# Altium schematic sheet Sheet2.SchDoc
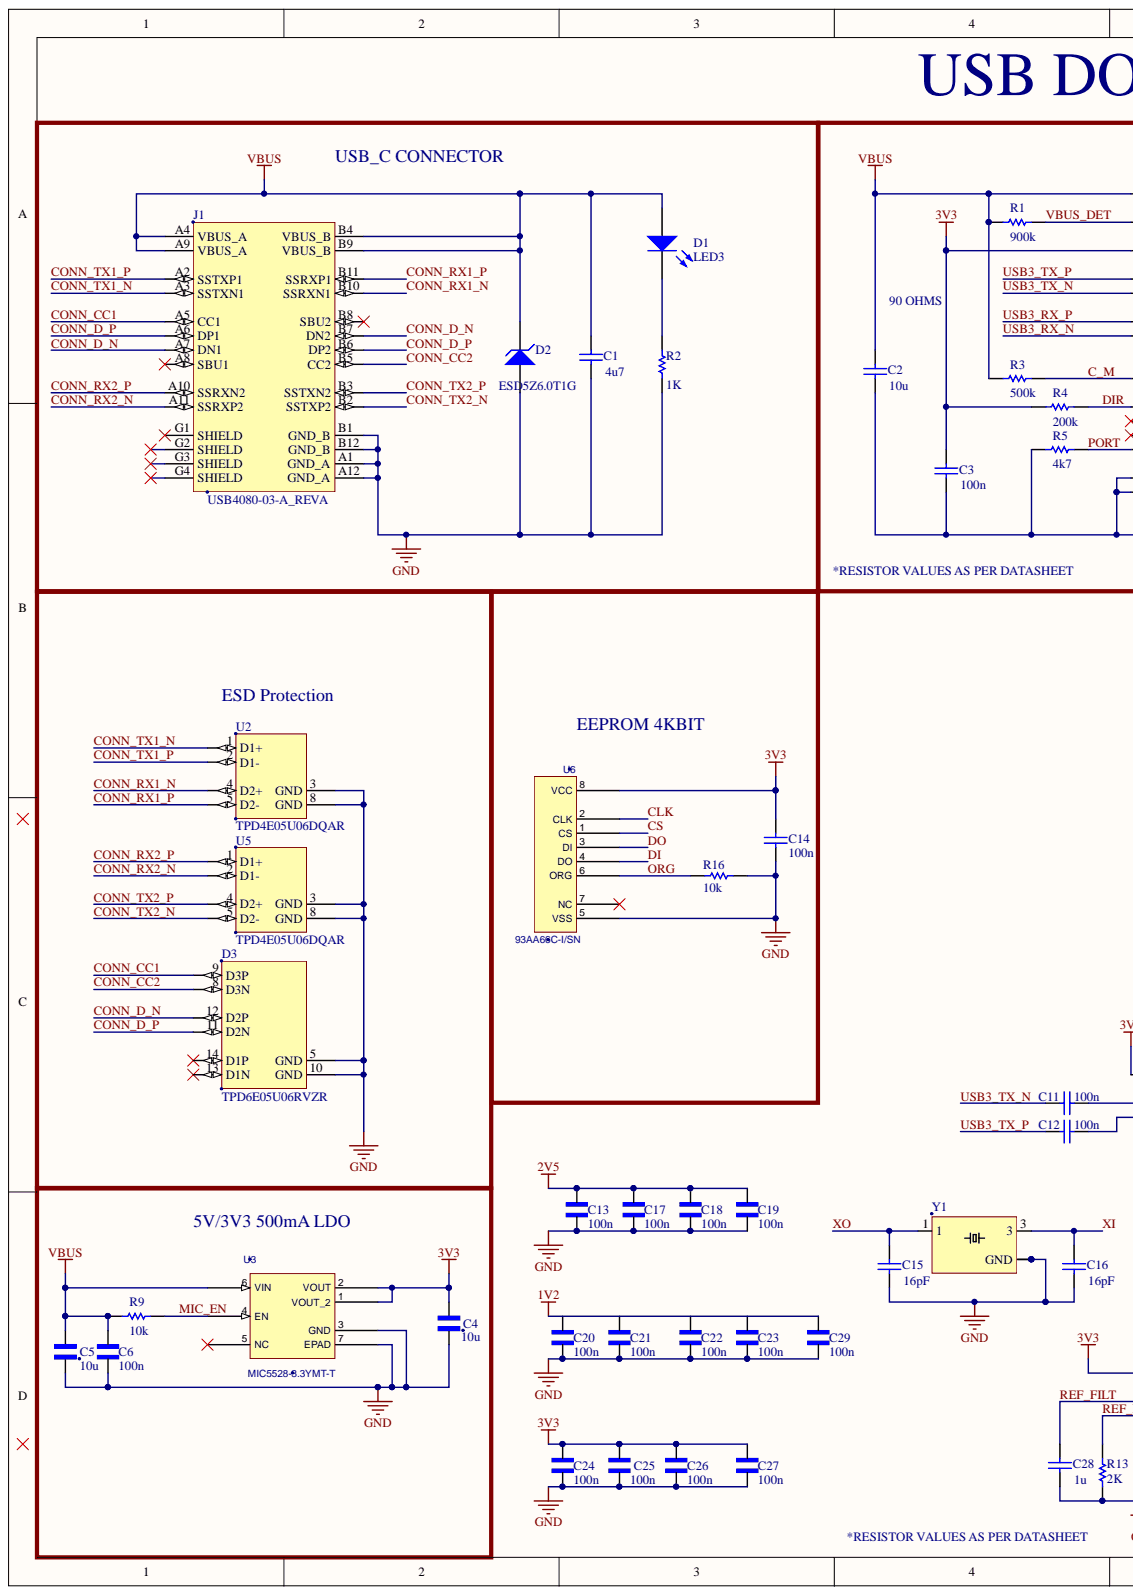
[diagram p0: Sheet2.SchDoc, left]
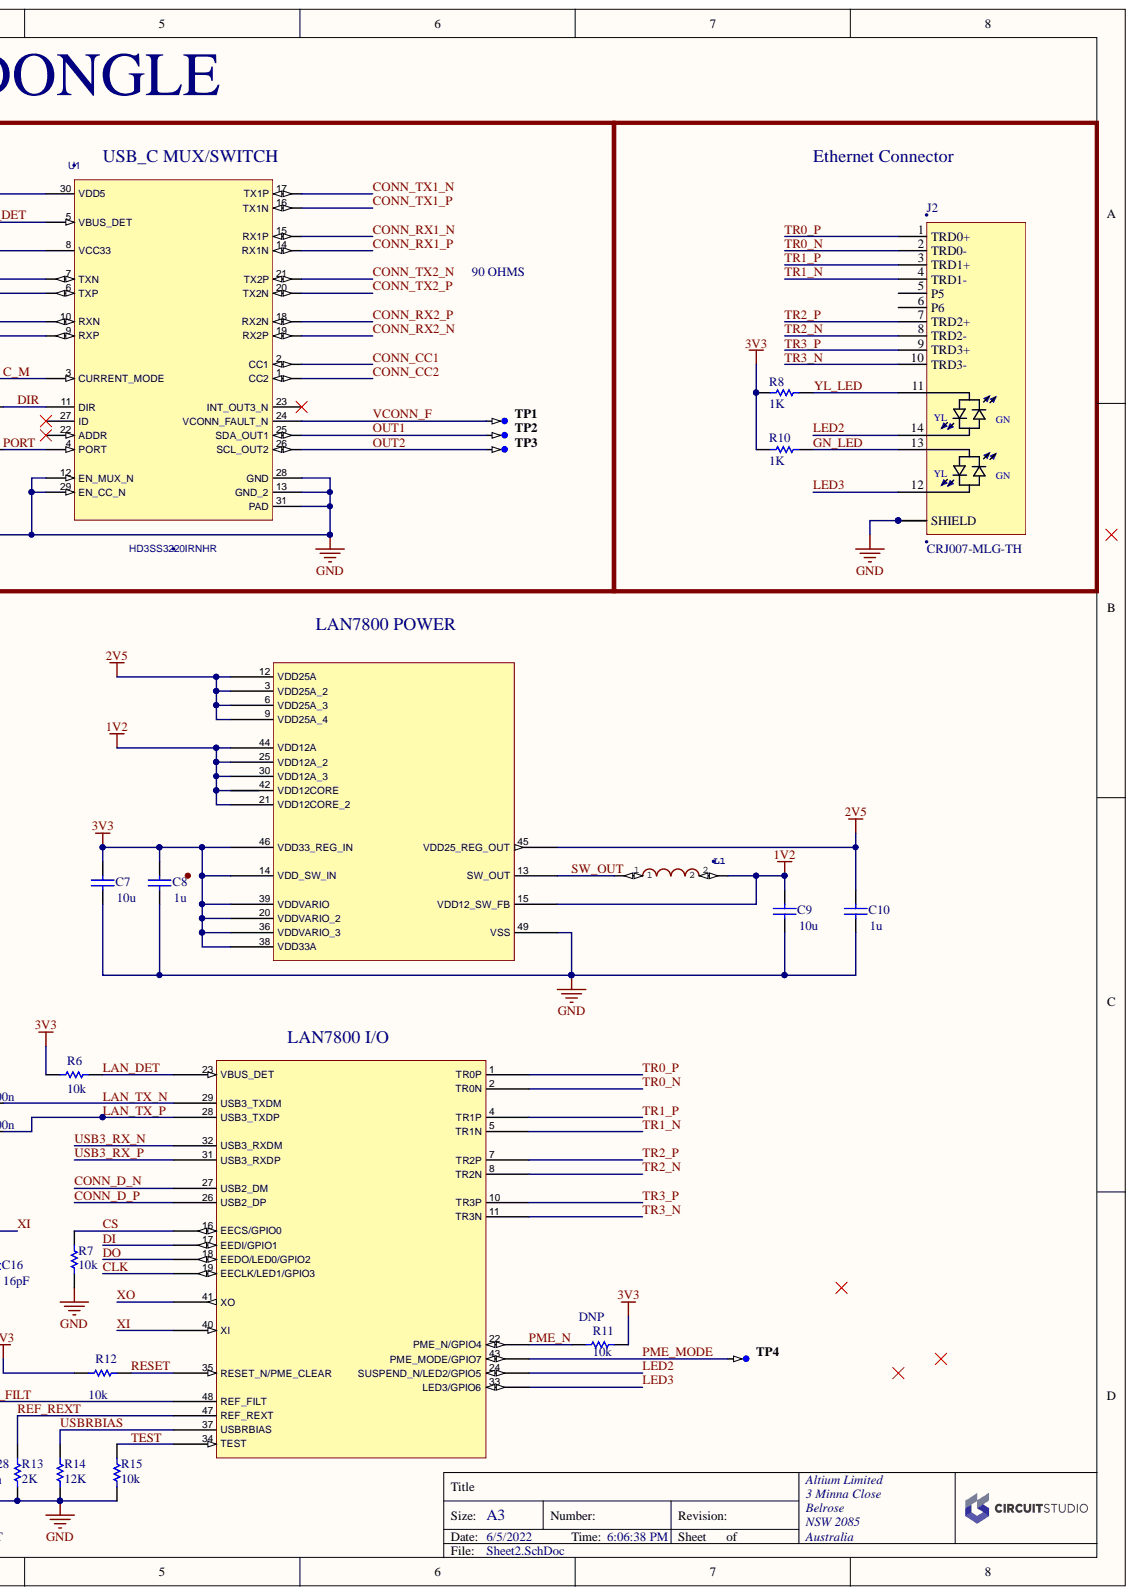
[diagram p1: Sheet2.SchDoc, right]

## Parts
C1  value 4u7  [1608[0603]]  (hidden comment: Cap)
C2  value 10u  [*CAPC1608X100N]  (hidden comment: Cap)
C3  value 100n  [*CAPC1005X55N]  (hidden comment: Cap)
C4  value 10u  [*CAPC1608X100N]  (hidden comment: GRM188R61E106MA73D)
C5  value 10u  [*CAPC1608X100N]  (hidden comment: GRM188R61E106MA73D)
C6  value 100n  [*CAPC1005X55N]  (hidden comment: CGA2B3X7R1V104K050BB)
C7  value 10u  [*CAPC1608X100N]  (hidden comment: Cap)
C8  value 1u  [*CAPC1005X55N]  (hidden comment: Cap)
C9  value 10u  [*CAPC1608X100N]  (hidden comment: Cap)
C10  value 1u  [*CAPC1005X55N]  (hidden comment: Cap)
C11  value 100n  [*CAPC1005X55N]  (hidden comment: Cap)
C12  value 100n  [*CAPC1005X55N]  (hidden comment: Cap)
C13  value 100n  [*CAPC1005X55N]  (hidden comment: CGA2B3X7R1V104K050BB)
C14  value 100n  [*CAPC1005X55N]  (hidden comment: Cap)
C15  value 16pF  [*CAPC1005X55N]  (hidden comment: Cap)
C16  value 16pF  [*CAPC1005X55N]  (hidden comment: Cap)
C17  value 100n  [*CAPC1005X55N]  (hidden comment: CGA2B3X7R1V104K050BB)
C18  value 100n  [*CAPC1005X55N]  (hidden comment: CGA2B3X7R1V104K050BB)
C19  value 100n  [*CAPC1005X55N]  (hidden comment: CGA2B3X7R1V104K050BB)
C20  value 100n  [*CAPC1005X55N]  (hidden comment: CGA2B3X7R1V104K050BB)
C21  value 100n  [*CAPC1005X55N]  (hidden comment: CGA2B3X7R1V104K050BB)
C22  value 100n  [*CAPC1005X55N]  (hidden comment: CGA2B3X7R1V104K050BB)
C23  value 100n  [*CAPC1005X55N]  (hidden comment: CGA2B3X7R1V104K050BB)
C24  value 100n  [*CAPC1005X55N]  (hidden comment: CGA2B3X7R1V104K050BB)
C25  value 100n  [*CAPC1005X55N]  (hidden comment: CGA2B3X7R1V104K050BB)
C26  value 100n  [*CAPC1005X55N]  (hidden comment: CGA2B3X7R1V104K050BB)
C27  value 100n  [*CAPC1005X55N]  (hidden comment: CGA2B3X7R1V104K050BB)
C28  value 1u  [*CAPC1005X55N]  (hidden comment: Cap)
C29  value 100n  [*CAPC1005X55N]  (hidden comment: CGA2B3X7R1V104K050BB)
D1  LED3  [*LEDC1608X65N]
D2  ESD5Z6.0T1G  [SODFL1608X70N]
D3  TPD6E05U06RVZR  [*SON50P135X350X55-14N]
J1  USB4080-03-A_REVA  [*GCT_USB4080-03-A_REVA_USB4080-03-A_REVA(Primary)]
J2  CRJ007-MLG-TH  [*CUI_CRJ007-MLG-TH_CRJ007-MLG-TH(Primary)]
L1  SMD / SMT Inductors (Coils), L=3.3?H, L x W x T :   Source:  Datasheet  [VLS3012]  (value not drawn)
R1  value 900k  [*RESC1005X37N]  (hidden comment: Res1)
R2  value 1K  [*RESC1005X37N]  (hidden comment: Res1)
R3  value 500k  [*RESC1005X37N]  (hidden comment: Res1)
R4  value 200k  [*RESC1005X37N]  (hidden comment: Res1)
R5  value 4k7  [*RESC1005X37N]  (hidden comment: Res1)
R6  value 10k  [*RESC1005X37N]  (hidden comment: Res1)
R7  value 10k  [*RESC1005X37N]  (hidden comment: Res1)
R8  value 1K  [*RESC1005X37N]  (hidden comment: Res1)
R9  value 10k  [*RESC1005X37N]  (hidden comment: Res1)
R10  value 1K  [*RESC1005X37N]  (hidden comment: Res1)
R11  DNP  value 10k  [*RESC1005X37N]
R12  value 10k  [*RESC1005X37N]  (hidden comment: Res1)
R13  value 2K  [*RESC1005X37N]  (hidden comment: Res1)
R14  value 12K  [*RESC1005X37N]  (hidden comment: Res1)
R15  value 10k  [*RESC1005X37N]  (hidden comment: Res1)
R16  value 10k  [*RESC1005X37N]  (hidden comment: Res1)
TP1  TP-SM-C-100  [TP-SM-C-100]  (value not drawn)  (no BOM)
TP2  TP-SM-C-100  [TP-SM-C-100]  (value not drawn)  (no BOM)
TP3  TP-SM-C-100  [TP-SM-C-100]  (value not drawn)  (no BOM)
TP4  TP-SM-C-100  [TP-SM-C-100]  (value not drawn)  (no BOM)
U1  HD3SS3220IRNHR  [RNH0030A]
U2  TPD4E05U06DQAR  [*TI_TPD4E05U06DQAR]
U3  MIC5528-3.3YMT-T  [TDFN6_1P2X1P2_00]
U4  LAN7800/Y9X  [SQFN48_7X7_Y9X00]  (value not drawn)  (parts A,B)
U5  TPD4E05U06DQAR  [*TI_TPD4E05U06DQAR]
U6  93AA66C-I/SN  [SOIC8-N_MC_MCH]
Y1  ABM8G-25.000MHZ-B4Y-T  [*OSCSC320X250X100N]  (value not drawn)

## Nets
1V2: C9.1 C29.1 L1.2 U4.15(VDD12_SW_FB) U4.21(VDD12CORE_2) U4.25(VDD12A_2) U4.30(VDD12A_3) U4.42(VDD12CORE) U4.44(VDD12A)
2V5: C10.1 C13.1 C17.1 C18.1 C19.1 U4.3(VDD25A_2) U4.6(VDD25A_3) U4.9(VDD25A_4) U4.12(VDD25A) U4.45(VDD25_REG_OUT)
3V3: C3.1 C4.1 C7.1 C8.1 C14.2 C24.1 C25.1 C26.1 C27.1 R4.1 R6.1 R8.2 R10.2 R11.1 R12.1 U1.8(VCC33) U3.1(VOUT_2) U3.2(VOUT) U4.14(VDD_SW_IN) U4.20(VDDVARIO_2) U4.36(VDDVARIO_3) U4.38(VDD33A) U4.39(VDDVARIO) U4.46(VDD33_REG_IN) U6.8(VCC)
GND: C1.2 C2.2 C3.2 C4.2 C5.2 C6.2 C7.2 C8.2 C9.2 C10.2 C13.2 C14.1 C15.2 C16.2 C17.2 C18.2 C19.2 C20.2 C21.2 C22.2 C23.2 C24.2 C25.2 C26.2 C27.2 C28.2 C29.2 D2.1 D3.5(GND) D3.10(GND) J1.A1(GND_A) J1.A12(GND_A) J1.B1(GND_B) J1.B12(GND_B) J2.S1(SHIELD) J2.S2 R2.2 R5.1 R7.1 R13.1 R14.1 R15.1 R16.1 U1.12(EN_MUX_N) U1.13(GND_2) U1.28(GND) U1.29(EN_CC_N) U1.31(PAD) U2.3(GND) U2.8(GND) U3.3(GND) U3.7(EPAD) U5.3(GND) U5.8(GND) U6.5(VSS) Y1.2(GND) Y1.4
VBUS: C1.1 C2.1 C5.1 C6.1 D1.1 D2.2 J1.A4(VBUS_A) J1.A9(VBUS_A) J1.B4(VBUS_B) J1.B9(VBUS_B) R1.1 R3.1 R9.1 U1.30(VDD5) U3.6(VIN)
C_M: R3.2 U1.3(CURRENT_MODE)
CLK: U4.19(EECLK/LED1/GPIO3) U6.2(CLK)
CONN_CC1: D3.9(D3P) J1.A5(CC1) U1.2(CC1)
CONN_CC2: D3.8(D3N) J1.B5(CC2) U1.1(CC2)
CONN_D_N: D3.12(D2P) J1.A7(DN1) J1.B7(DN2) U4.27(USB2_DM)
CONN_D_P: D3.11(D2N) J1.A6(DP1) J1.B6(DP2) U4.26(USB2_DP)
CONN_RX1_N: J1.B10(SSRXN1) U1.15(RX1P) U2.4(D2+)
CONN_RX1_P: J1.B11(SSRXP1) U1.14(RX1N) U2.5(D2-)
CONN_RX2_N: J1.A11(SSRXP2) U1.19(RX2P) U5.2(D1-)
CONN_RX2_P: J1.A10(SSRXN2) U1.18(RX2N) U5.1(D1+)
CONN_TX1_N: J1.A3(SSTXN1) U1.17(TX1P) U2.1(D1+)
CONN_TX1_P: J1.A2(SSTXP1) U1.16(TX1N) U2.2(D1-)
CONN_TX2_N: J1.B2(SSTXP2) U1.21(TX2P) U5.5(D2-)
CONN_TX2_P: J1.B3(SSTXN2) U1.20(TX2N) U5.4(D2+)
CS: R7.2 U4.16(EECS/GPIO0) U6.1(CS)
DI: U4.17(EEDI/GPIO1) U6.4(DO)
DIR: R4.2 U1.11(DIR)
DO: U4.18(EEDO/LED0/GPIO2) U6.3(DI)
GN_LED: J2.13 R10.1
LAN_DET: R6.2 U4.23(VBUS_DET)
LAN_TX_N: C11.2 U4.29(USB3_TXDM)
LAN_TX_P: C12.2 U4.28(USB3_TXDP)
LED2: J2.14 U4.24(SUSPEND_N/LED2/GPIO5)
LED3: J2.12 U4.33(LED3/GPIO6)
MIC_EN: R9.2 U3.4(EN)
ORG: R16.2 U6.6(ORG)
OUT1: TP2.1 U1.25(SDA_OUT1)
OUT2: TP3.1 U1.26(SCL_OUT2)
PME_MODE: TP4.1 U4.43(PME_MODE/GPIO7)
PME_N: R11.2 U4.22(PME_N/GPIO4)
PORT: R5.2 U1.4(PORT)
REF_FILT: C28.1 U4.48(REF_FILT)
REF_REXT: R13.2 U4.47(REF_REXT)
RESET: R12.2 U4.35(RESET_N/PME_CLEAR)
SW_OUT: L1.1 U4.13(SW_OUT)
TEST: R15.2 U4.34(TEST)
TR0_N: J2.2(TRD0-) U4.2(TR0N)
TR0_P: J2.1(TRD0+) U4.1(TR0P)
TR1_N: J2.4(TRD1-) U4.5(TR1N)
TR1_P: J2.3(TRD1+) U4.4(TR1P)
TR2_N: J2.8(TRD2-) U4.8(TR2N)
TR2_P: J2.7(TRD2+) U4.7(TR2P)
TR3_N: J2.10(TRD3-) U4.11(TR3N)
TR3_P: J2.9(TRD3+) U4.10(TR3P)
USB3_RX_N: U1.9(RXP) U4.32(USB3_RXDM)
USB3_RX_P: U1.10(RXN) U4.31(USB3_RXDP)
USB3_TX_N: C11.1 U1.6(TXP)
USB3_TX_P: C12.1 U1.7(TXN)
USBRBIAS: R14.2 U4.37(USBRBIAS)
VBUS_DET: R1.2 U1.5(VBUS_DET)
VCONN_F: TP1.1 U1.24(VCONN_FAULT_N)
XI: C16.1 U4.40(XI) Y1.3
XO: C15.1 U4.41(XO) Y1.1
YL_LED: J2.11 R8.1
NetD1_2: D1.2 R2.1

Not connected: C20 1; C21 1; C22 1; C23 1; D3 13(D1N) 14(D1P); J1 A8(SBU1) B8(SBU2) G1(SHIELD) G2(SHIELD) G3(SHIELD) G4(SHIELD); J2 5(P5) 6(P6); U1 22(ADDR) 23(INT_OUT3_N) 27(ID); U3 5(NC); U4 49(VSS); U6 7(NC)

## Library data of the parts
C1 = Cap: GRT188C81C475KE13J; Component Kind: Standard; LatestRevisionDate: 17-Jul-2002; LatestRevisionNote: Re-released for DXP Platform.; Library Name: Miscellaneous Devices.IntLib; PackageDescription: Radial Cap, Thru-Hole; 2 Leads; 0.3 in Pin Spacing; PackageReference: RAD-0.3; Published: 8-Jun-2000; Simulation: CAP; Publisher: Altium Limited
C2 C7 C9 = Cap: GRM188R61E106MA73D; Component Kind: Standard; LatestRevisionDate: 17-Jul-2002; LatestRevisionNote: Re-released for DXP Platform.; Library Name: Miscellaneous Devices.IntLib; PackageDescription: Radial Cap, Thru-Hole; 2 Leads; 0.3 in Pin Spacing; PackageReference: RAD-0.3; Published: 8-Jun-2000; Simulation: CAP; Publisher: Altium Limited
C3 C11 C12 C14 = Cap: CGA2B3X7R1V104K050BB; Component Kind: Standard; LatestRevisionDate: 17-Jul-2002; LatestRevisionNote: Re-released for DXP Platform.; Library Name: Miscellaneous Devices.IntLib; PackageDescription: Radial Cap, Thru-Hole; 2 Leads; 0.3 in Pin Spacing; PackageReference: RAD-0.3; Published: 8-Jun-2000; Simulation: CAP; Publisher: Altium Limited
C8 C10 C28 = Cap: CL05A105KO5NNNC; Component Kind: Standard; LatestRevisionDate: 17-Jul-2002; LatestRevisionNote: Re-released for DXP Platform.; Library Name: Miscellaneous Devices.IntLib; PackageDescription: Radial Cap, Thru-Hole; 2 Leads; 0.3 in Pin Spacing; PackageReference: RAD-0.3; Published: 8-Jun-2000; Simulation: CAP; Publisher: Altium Limited
C15 C16 = Cap: GRM1555C1H160JA01D; Component Kind: Standard; LatestRevisionDate: 17-Jul-2002; LatestRevisionNote: Re-released for DXP Platform.; Library Name: Miscellaneous Devices.IntLib; PackageDescription: Radial Cap, Thru-Hole; 2 Leads; 0.3 in Pin Spacing; PackageReference: RAD-0.3; Published: 8-Jun-2000; Simulation: CAP; Publisher: Altium Limited
D1 = LED3: LTST-C191KGKT; Component Kind: Standard; DatasheetVersion: 16-Apr-2002; LatestRevisionDate: 17-Dec-2003; LatestRevisionNote: PCB Footprint replaced.; Library Name: Miscellaneous Devices.IntLib; PackageDescription: SM LED; 2 C-Bend Leads; Body 3.5 x 2.8 x 1.9 mm, inc leads (LxWxH typ); PackageReference: 3.5X2.8X1.9; PackageVersion: 16-Apr-2002; Published: 8-Jun-2000; Simulation: LED3; Publisher: Altium Limited
D2 = D Zener: Component Kind: Standard; Description: ESD5Z6.0T1G; LatestRevisionDate: 17-Jul-2002; LatestRevisionNote: Re-released for DXP Platform.; Library Name: Miscellaneous Devices.IntLib; PackageDescription: Diode, Thru-Hole; 2 Leads; 0.7 in Pin Spacing; PackageReference: DIODE-0.7; Published: 8-Jun-2000; Simulation: ZENER; Publisher: Altium Limited
L1 = VLS3012HBX-3R3M-N: Manufacturer_Name: TDK; GateName_1: G$1; Component Kind: Standard; DeviceSetName: VLS3012HBX-3R3M-N; Height: mm; Library Name: VLS3012HBX-3R3M-N.IntLib; LibraryName: VLS3012HBX-3R3M-N; Manufacturer_Part_Number: VLS3012HBX-3R3M-N; Mouser_Part_Number: 810-VLS3012HBX3R3M-N; Mouser_Price-Stock: https://www.mouser.co.uk/ProductDetail/TDK/VLS3012HBX-3R3M-N?qs=A6eO%252BMLsxmSt5gC%2Frxbr%2FA%3D%3D; SymbolName_1: VLS3012HBX-3R3M-N
R1 = Res1: RC0402FR-07909RL; Component Kind: Standard; LatestRevisionDate: 17-Jul-2002; LatestRevisionNote: Re-released for DXP Platform.; Library Name: Miscellaneous Devices.IntLib; PackageDescription: Axial Device, Thru-Hole; 2 Leads; 0.3 in Pin Spacing; PackageReference: AXIAL-0.3; Published: 8-Jun-2000; Simulation: RESISTOR; Publisher: Altium Limited
R2 R8 R10 = Res1: AC0402FR-071KL; Component Kind: Standard; LatestRevisionDate: 17-Jul-2002; LatestRevisionNote: Re-released for DXP Platform.; Library Name: Miscellaneous Devices.IntLib; PackageDescription: Axial Device, Thru-Hole; 2 Leads; 0.3 in Pin Spacing; PackageReference: AXIAL-0.3; Published: 8-Jun-2000; Simulation: RESISTOR; Publisher: Altium Limited
R3 = Res1: AC0402FR-07499KL; Component Kind: Standard; LatestRevisionDate: 17-Jul-2002; LatestRevisionNote: Re-released for DXP Platform.; Library Name: Miscellaneous Devices.IntLib; PackageDescription: Axial Device, Thru-Hole; 2 Leads; 0.3 in Pin Spacing; PackageReference: AXIAL-0.3; Published: 8-Jun-2000; Simulation: RESISTOR; Publisher: Altium Limited
R4 = Res1: RC0402FR-07200KL; Value: 200k; Component Kind: Standard; LatestRevisionDate: 17-Jul-2002; LatestRevisionNote: Re-released for DXP Platform.; Library Name: Miscellaneous Devices.IntLib; PackageDescription: Axial Device, Thru-Hole; 2 Leads; 0.3 in Pin Spacing; PackageReference: AXIAL-0.3; Published: 8-Jun-2000; Simulation: RESISTOR; Publisher: Altium Limited
R5 = Res1: AC0402FR-134K7L; Component Kind: Standard; LatestRevisionDate: 17-Jul-2002; LatestRevisionNote: Re-released for DXP Platform.; Library Name: Miscellaneous Devices.IntLib; PackageDescription: Axial Device, Thru-Hole; 2 Leads; 0.3 in Pin Spacing; PackageReference: AXIAL-0.3; Published: 8-Jun-2000; Simulation: RESISTOR; Publisher: Altium Limited
R6 R7 R9 R11 R12 R15 R16 = Res1: AC0402FR-0710KL; Component Kind: Standard; LatestRevisionDate: 17-Jul-2002; LatestRevisionNote: Re-released for DXP Platform.; Library Name: Miscellaneous Devices.IntLib; PackageDescription: Axial Device, Thru-Hole; 2 Leads; 0.3 in Pin Spacing; PackageReference: AXIAL-0.3; Published: 8-Jun-2000; Simulation: RESISTOR; Publisher: Altium Limited
R13 = Res1: ERJ-2RKF2001X; Component Kind: Standard; LatestRevisionDate: 17-Jul-2002; LatestRevisionNote: Re-released for DXP Platform.; Library Name: Miscellaneous Devices.IntLib; PackageDescription: Axial Device, Thru-Hole; 2 Leads; 0.3 in Pin Spacing; PackageReference: AXIAL-0.3; Published: 8-Jun-2000; Simulation: RESISTOR; Publisher: Altium Limited
R14 = Res1: RC0402FR-1312KL; Component Kind: Standard; LatestRevisionDate: 17-Jul-2002; LatestRevisionNote: Re-released for DXP Platform.; Library Name: Miscellaneous Devices.IntLib; PackageDescription: Axial Device, Thru-Hole; 2 Leads; 0.3 in Pin Spacing; PackageReference: AXIAL-0.3; Published: 8-Jun-2000; Simulation: RESISTOR; Publisher: Altium Limited
TP1-TP4 = CMP-0484-00002-1: Test Point, Surface Mount, Circular, Pad Diameter 1 mm; Library Name: Altium Content Vault
U1 = HD3SS3220IRNHR: Mfr_Name: Texas Instruments; Value: TYPE; Component Kind: Standard; Library Name: HD3SS.SchLib; Manufacturer_Part_Number: HD3SS3220IRNHR; Copyright: Copyright (C) 2022 Ultra Librarian. All rights reserved.
U3 = MIC5528-3.3YMT-T: Manufacturer_Part_Number: MIC5528-3.3YMT-TR; Mfr_Name: Microchip; Component Kind: Standard; Library Name: mic5528.SchLib; Value: MIC5528-3.3YMT-T; Copyright: Copyright (C) 2022 Ultra Librarian. All rights reserved.
U4 = LAN7800/Y9X: Mfr_Name: Microchip; C: Copyright (C) 2018 Accelerated Designs. All rights reserved; Component Kind: Standard; Library Name: lan7800.SchLib; Manufacturer_Part_Number: LAN7800/Y9X; Value: LAN7800/Y9X; Copyright: Copyright (C) 2022 Ultra Librarian. All rights reserved.
U6 = 93AA66C-I/SN: Mfr_Name: Microchip; Component Kind: Standard; Library Name: 93AA66C.SchLib; Manufacturer_Part_Number: 93AA66C-I/SN; Value: 93AA66C-I/SN; Copyright: Copyright (C) 2022 Ultra Librarian. All rights reserved.
C4 C5 = GRM188R61E106MA73D: Component Kind: Standard; Library Name: GRM188R61E106MA73D.SchLib
C6 C13 C17-C27 C29 = CGA2B3X7R1V104K050BB: Component Kind: Standard; Library Name: CGA2B3X7R1V104K050BB.SchLib
D3 = TPD6E05U06RVZR: Component Kind: Standard; Library Name: TPD6E05U06RVZR.SchLib
J1 = USB4080-03-A_REVA: Component Kind: Standard; Library Name: USB4080-03-A_REVA.SchLib
J2 = CRJ007-MLG-TH: Component Kind: Standard; Library Name: CRJ007-MLG-TH.SCHLIB
U2 U5 = TPD4E05U06DQAR: Component Kind: Standard; Library Name: TPD4E05U06DQAR.SchLib
Y1 = ABM8G-25.000MHZ-B4Y-T: Component Kind: Standard; Library Name: ABM8G-25.000MHZ-B4Y-T.SchLib
